# Altium schematic sheet Sheet1.SchDoc
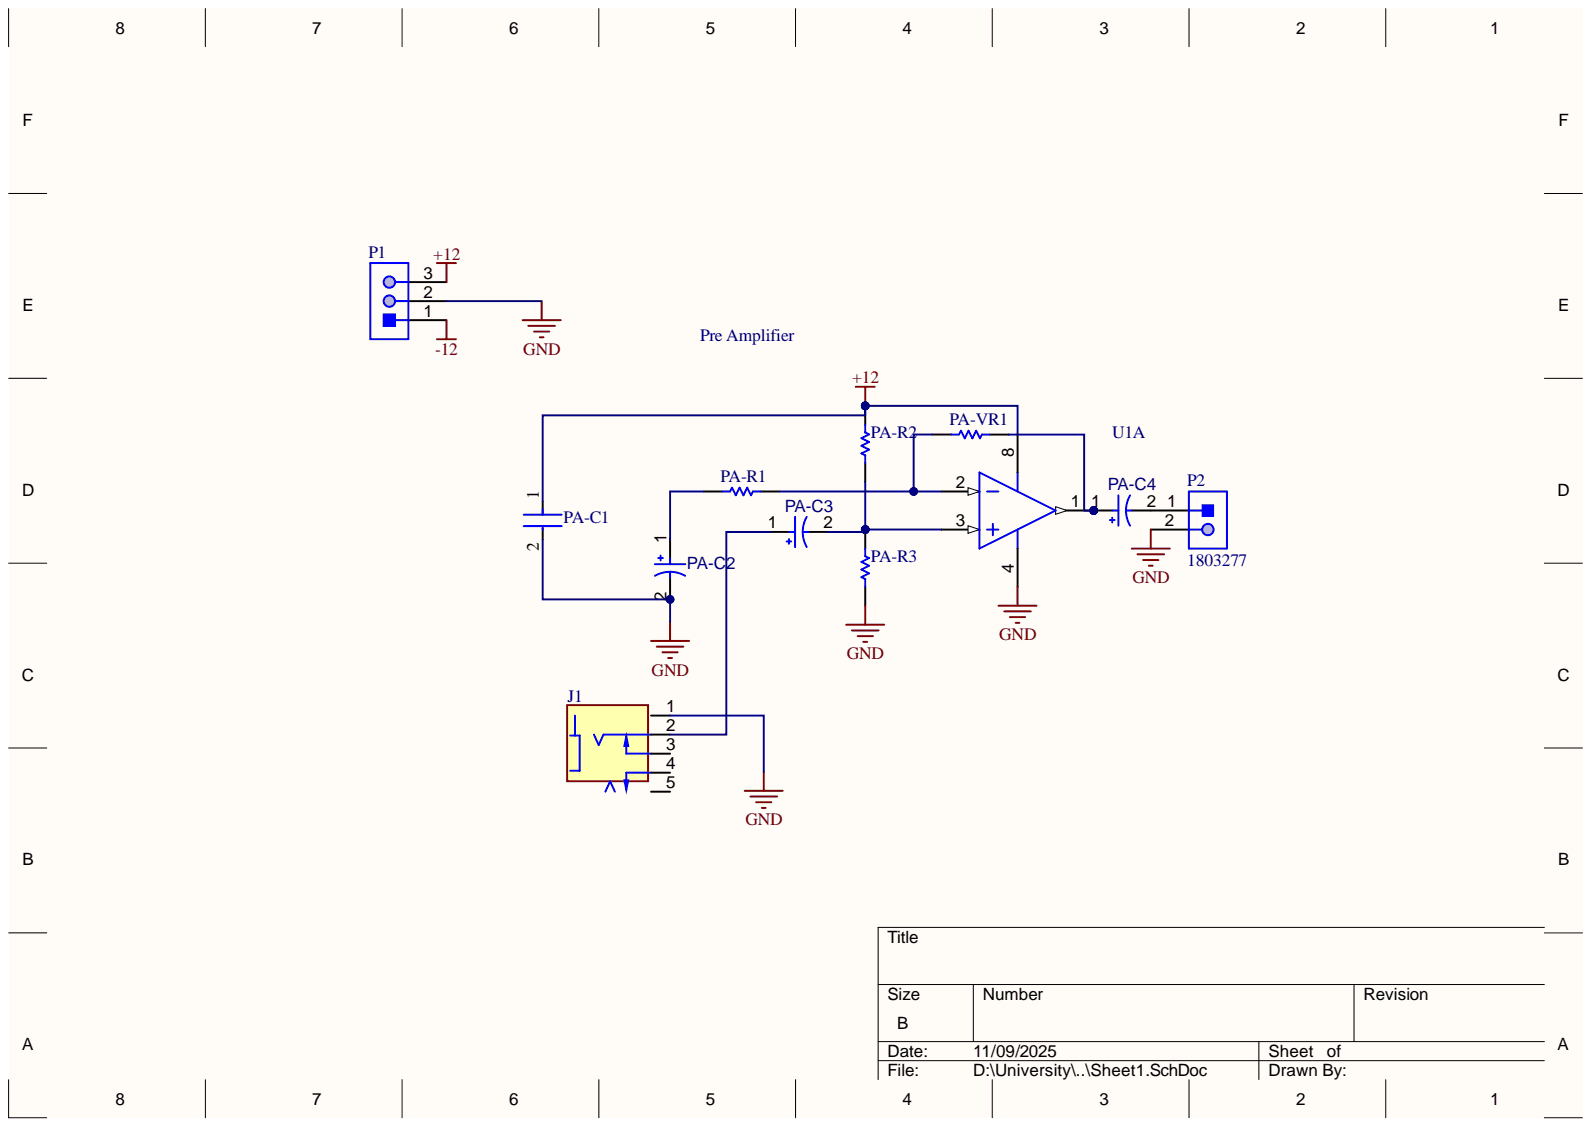
[diagram p0: Sheet1.SchDoc]

## Parts
J1  35RAPC4BH3  [SWITCHCRAFT_35RAPC4BH3]  (value not drawn)
P1  B3P-VH(LF)(SN)  [JST-B3P-VH(LF)(SN)-3_V]  (value not drawn)
P2  1803277  [PHOE-1803277_V]
PA-C1  100n  [FP-VY2102M29Y5US6TV7-MFG]  (value not drawn)
PA-C2  ECA1HM100  [CAPPR55-200-1100X500X1200]  (value not drawn)
PA-C3  ECA-1HHG010  [CAPPR55-200-1100X500X1200]  (value not drawn)
PA-C4  ECA1HM100  [CAPPR55-200-1100X500X1200]  (value not drawn)
PA-R1  MFR-25FBF52-2K21  [RESA60-630X240]  (value not drawn)
PA-R2  100k  [RESA60-630X240]  (value not drawn)
PA-R3  100k  [RESA60-630X240]  (value not drawn)
PA-VR1  MFR-25FBF52-2K21  [RESA60-630X240]  (value not drawn)
U1  NE5532P  [P0008A]  (value not drawn)  (part A)

## Nets
+12: P1.3 PA-C1.1 PA-R2.2 U1.8
-12: P1.1
GND: J1.1 P1.2 P2.2 PA-C1.2 PA-C2.2 PA-R3.1 U1.4
NetJ1_2: J1.2 PA-C3.1
NetP2_1: P2.1 PA-C4.2
NetPA-C2_1: PA-C2.1 PA-R1.1
NetPA-C3_2: PA-C3.2 PA-R2.1 PA-R3.2 U1.3
NetPA-C4_1: PA-C4.1 PA-VR1.2 U1.1
NetPA-R1_2: PA-R1.2 PA-VR1.1 U1.2

Not connected: J1 3 4 5

## Library data of the parts
J1 = 35RAPC4BH3: PC horizontal mount Jack stereo threaded bushing 3.5MM, 35 Series | Switchcraft 35RAPC4BH3; MF: Switchcraft Inc.; Check_prices: https://www.snapeda.com/parts/35RAPC4BH3/SWITCHCRAFT/view-part/?ref=eda; Component Kind: Standard; Library Name: 35RAPC4BH3.IntLib; MP: 35RAPC4BH3; Package: None; SnapEDA_Link: https://www.snapeda.com/parts/35RAPC4BH3/SWITCHCRAFT/view-part/?ref=snap
P1 = CMP-002-00026-2: Male Header, Pitch 3.96 mm, 1 x 3 Position, Height 10.9 mm, Tail Length 3.7 mm, -25 to 85 degC, RoHS, Bulk; Manufacturer: JST; Case/Package: B3P-VH(LF)(SN); Component Kind: Standard; Connector Type: Header; Datasheet URL: http://www.jst-mfg.com/product/pdf/eng/eVH.pdf; Datasheet Version: May-16; Gender: Male; Manufacturer URL: http://www.jst.com/home2.html; Max Operating Temperature: 85°C; Min Operating Temperature: -25°C; Mounting Technology: TH; Package Description: Male Header, Pitch 3.96 mm, 1 x 3 Position, Height 10.9 mm, Tail Length 3.7 mm; Package Reference: B3P-VH(LF)(SN); RoHS Compliant: Unknown; Library Name: Senuda Ratnayake
P2 = CMP-002-00014-2: Male Header, Pitch 3.81 mm, 1 x 2 Position, Height 7.25 mm, Tail Length 3.4 mm, RoHS, Bulk; Manufacturer: Phoenix Contact; Current Rating: 8A; Rows: 1; Voltage Rating: 160V; Case/Package: 1803277; Colour: Green; Component Kind: Standard; Connector Type: Header; Contact Plating: Tin; Datasheet URL: https://datasheet.ciiva.com/16096/1803277-16096845.pdf; Datasheet Version: Feb-14; Diameter: 7.25mm; Gender: Male; Lead Pitch: 0.15inch; Manufacturer URL: http://www.phoenixcontact.com/; Max Current Rating: 8A; Mounting Technology: TH; Package Description: Male Header, Pitch 3.81 mm, 1 x 2 Position, Height 7.25 mm, Tail Length 3.4 mm; Package Quantity: 50; Package Reference: 1803277; Packaging: Bulk; Pins: 2; RoHS Compliant: True; Library Name: Senuda Ratnayake
PA-C1 = CMP-00030-42817980-1: VY2102M29Y5US6TV7 Cap Ceramic Suppression X1/Y2 0.001uF 440VAC/300VAC Y5U 20% (7.5 X 5mm) Radial 7.5mm 125C T/R; Capacitance: 1nF; Lead Diameter: 600µm; Lead/Base Style: Kinked; Voltage: 300V; Voltage Rating: 1kV; Case/Package: Radial; Component Kind: Standard; Composition: Ceramic; Depth: 5mm; Diameter: 7.5mm; Dielectric: Y5U; Height: 10.5mm; Height - Seated (Max): 11.5062mm; Lead Free: Lead Free; Lead Pitch: 7.5mm; Library Name: Altium Content Vault; Material: Ceramic; Max Operating Temperature: 125°C; Min Operating Temperature: -40°C; Mount: Through Hole; NexarPartId: 42817980; Packaging: Tape & Reel (TR); Radiation Hardening: No; REACH SVHC: Unknown; RoHS: Compliant; Schedule B: 8532230060, 8532230060; Termination: Radial; Tolerance: 20%; Voltage Rating (AC): 300V
PA-C2 PA-C4 = CMP-001-00025-7: Aluminum Electrolytic Capacitor, 10 uF, +/- 20%, 50 V, -40 to 85 degC, 2-Pin THD, RoHS, Bulk; Manufacturer: Panasonic; Ripple Current: 65mA; Value: 10µF; Voltage Rating: 50V; Capacitor Type: Electrolytic; Case/Package: Radial; Component Kind: Standard; Datasheet URL: https://industrial.panasonic.com/cdbs/www-data/pdf/RDF0000/ABA0000C1218.pdf; Datasheet Version: Rev. 05, 10/2016; Diameter: 5mm; Lead Pitch: 2mm; Manufacturer URL: http://www.panasonic.com/; Max Operating Temperature: 85°C; Min Operating Temperature: -40°C; Mounting Technology: TH; Package Description: 2-Pin Radial Lead, Dia 5 mm, Height 12 mm; Package Quantity: 200; Package Reference: CAPPR55-200-1100X500X1200; Packaging: Bulk; Pins: 2; Ripple Current (AC): 65mA; RoHS Compliant: True; Tolerance: 20%; Library Name: Senuda Ratnayake
PA-C3 = CMP-001-00024-7: Aluminum Electrolytic Capacitor, 1 uF, +/- 20%, 50 V, -55 to 105 degC, 2-Pin THD, RoHS, Bulk; Manufacturer: Panasonic; Ripple Current: 10mA; Value: 1µF; Voltage Rating: 50V; Capacitor Type: Electrolytic; Case/Package: Radial; Component Kind: Standard; Datasheet URL: https://datasheet.ciiva.com/7275/94060-7275472.pdf; Datasheet Version: Mar-05; Diameter: 5mm; Lead Pitch: 2mm; Manufacturer URL: http://www.panasonic.com/; Max Operating Temperature: 105°C; Min Operating Temperature: -55°C; Mounting Technology: TH; Package Description: 2-Pin Radial Lead, Dia 5 mm, Height 12 mm; Package Quantity: 200; Package Reference: CAPPR55-200-1100X500X1200; Packaging: Bulk; Pins: 2; Ripple Current (AC): 10mA; RoHS Compliant: True; Tolerance: 20%; Library Name: Senuda Ratnayake
PA-R1 PA-VR1 = CMP-1659-00065-1: Axial Resistor, 2.21 KOhm, +/- 1%, 0.25 W, -55 to 155 degC, 2-Pin THD, RoHS, Bulk; Case\Package: 0402; Current Rating: 300 mA; Resistance: 0.15 Ohm; Shielding: Unshielded; Case Code (Imperial): 0402; Case Code (Metric): 1005; Component Kind: Standard; ComponentLink1Description: Manufacturer URL; ComponentLink1URL: http://www.yageo.com/; ComponentLink2Description: Datasheet; ComponentLink2URL: http://www.yageo.com/documents/recent/Yageo%20LR_MFR_2013.pdf; DatasheetVersion: Apr-13; Height: 0.02 inch; Length: 0.04 inch; Library Name: Altium Content Vault; Max Operating Temperature: 125 degC; Min Operating Temperature: -55 degC; Mount: Surface Mount; Package Quantity: 10000; PackageDescription: 2-Pin Axial Lead, Dia 2.4 mm, Body Length 6.3 mm; PackageReference: MFR-25; Packaging: Tape and Reel; Pins: 2; Radiation Hardening: No; REACH SVHC: No SVHC; RoHSCompliant: true; Width: 0.02 inch
PA-R2 PA-R3 = CMP-1659-00073-1: Axial Resistor, 100 KOhm, +/- 1%, 0.25 W, -55 to 155 degC, 2-Pin THD, RoHS, Bulk; Power Rating: 250 mW; Resistance: 475 Ohm; Voltage Rating: 250 V; Case\Package: Axial; Component Kind: Standard; ComponentLink1Description: Manufacturer URL; ComponentLink1URL: http://www.yageo.com/; ComponentLink2Description: Datasheet; ComponentLink2URL: http://www.yageo.com/documents/recent/Yageo%20LR_MFR_2013.pdf; DatasheetVersion: Apr-13; Length: 0.248 inch; Library Name: Altium Content Vault; Max Operating Temperature: 155 degC; Min Operating Temperature: -55 degC; Mount: Through Hole; Package Quantity: 200; PackageDescription: 2-Pin Axial Lead, Dia 2.4 mm, Body Length 6.3 mm; PackageReference: MFR-25; Packaging: Bulk; RoHSCompliant: true; Tolerance: 1%
U1 = CMP-0017-00910-2: Dual Low-Noise High-Speed Audio Operational Amplifier, 10 to 30 V, 0 to 70 degC, 8-pin DIP (P8), Pb-Free (RoHS); Manufacturer: Texas Instruments; CMRR(Min)(dB): 70; GBW(Typ)(MHz): 10; IIB(Max)(pA): 800000; Iq per channel(Max)(mA): 4; Number of Channels: 2; Slew Rate(Typ)(V/us): 9; Sub Family: General Purpose Amplifier, High Supply Voltage Amplifier (>=30V), Low Noise Amp (<=10nV/rtHz); Total Supply Voltage(Max)(+5V is 5, +/-5V is 10): 30; Vn at 1kHz(Typ)(nV/rtHz): 5; Code_JEDEC: MS-001; Component Kind: Standard; ComponentLink1Description: Manufacturer URL; ComponentLink1URL: http://www.ti.com/; ComponentLink2Description: Datasheet; ComponentLink2URL: http://www.ti.com/lit/ds/symlink/ne5532.pdf; ComponentLink3Description: Package Specification; ComponentLink3URL: http://www.ti.com/litv/pdf/mpdi001b; DatasheetVersion: SLOS075I; Eco-Plan: Pb-Free (RoHS); Library Name: Altium Content Vault; Mounting Technology: Through Hole; PackageDescription: 8-Pin Plastic In-Line, Body 9.59 x 6.35 mm, Pitch 2.54 mm; PackageReference: P0008A; PackageVersion: 4040082/E, 04/2010; PartNumber: NE5532P; Total Supply Voltage(Min)(+5V is 5, +/-5V is 10): 10; Vio (25C)(Max)(mV): 4
